# Altium schematic sheet GNSSModules.SchDoc(GNSSModules)
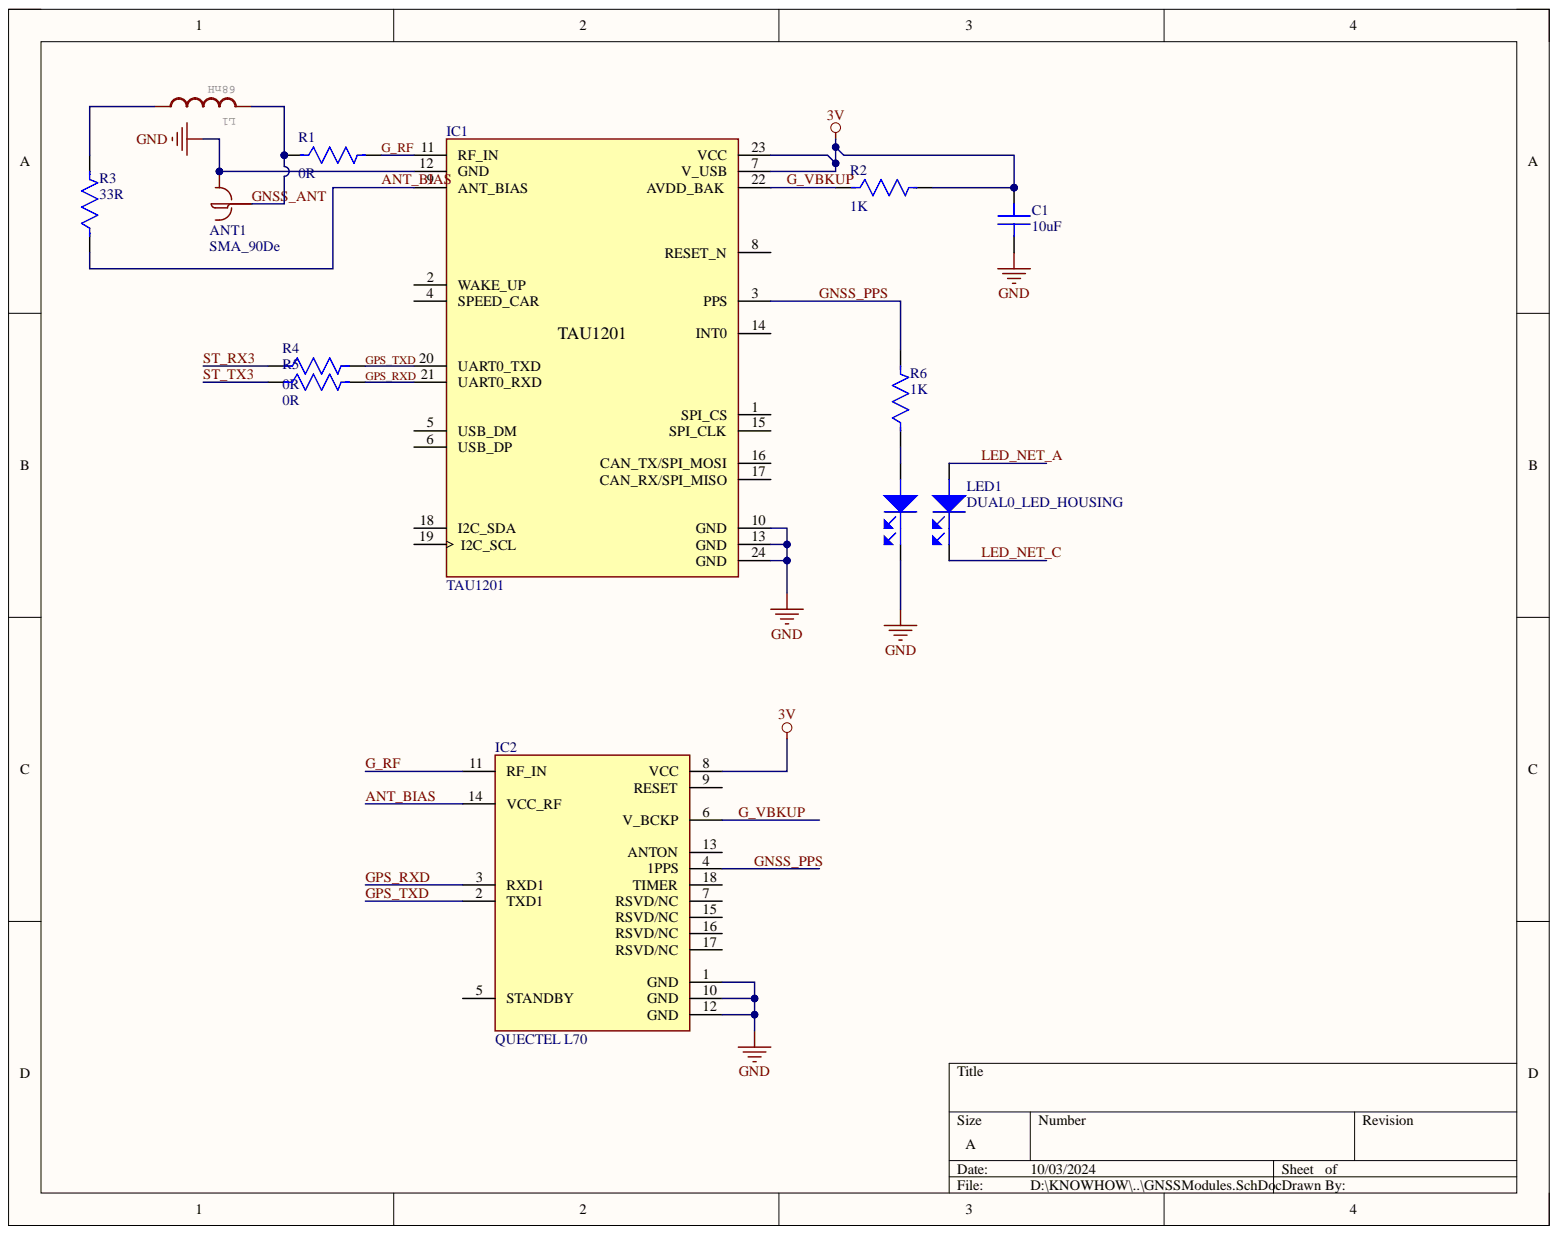
[diagram p0: GNSSModules.SchDoc]

## Parts
ANT1  SMA_90De  [SMA_90De]
C1  10uF  [C0603]
IC1  TAU1201  [GNSS_24PIN]
IC2  QUECTEL L70  MP: L70  [XCVR_L70]
L1  68nH  [C0603]
LED1  DUAL0_LED_HOUSING  [DUAL LED HOUSING]
R1  0R  [R0603]
R2  1K  [R0603]
R3  33R  [R0603]
R4  0R  [R0603]
R5  0R  [R0603]
R6  1K  [R0603]

## Nets
3V: C1.1 IC1.7(V_USB) IC1.23(VCC) IC2.8(VCC) R2.2
GND: ANT1.2, 3, 4, 5 C1.2 IC1.10(GND) IC1.12(GND) IC1.13(GND) IC1.24(GND) IC2.1(GND) IC2.10(GND) IC2.12(GND) LED1.4
ANT_BIAS: IC1.9(ANT_BIAS) IC2.14(VCC_RF) R3.1
G_RF: IC1.11(RF_IN) IC2.11(RF_IN) R1.2
G_VBKUP: IC1.22(AVDD_BAK) IC2.6(V_BCKP) R2.1
GNSS_ANT: ANT1.1 L1.2 R1.1
GNSS_PPS: IC1.3(PPS) IC2.4(1PPS) R6.2
GPS_RXD: IC1.21(UART0_RXD) IC2.3(RXD1) R5.2
GPS_TXD: IC1.20(UART0_TXD) IC2.2(TXD1) R4.2
LED_NET_A: LED1.1
LED_NET_C: LED1.2
ST_RX3: R4.1
ST_TX3: R5.1
NetL1_1: L1.1 R3.2
NetLED1_3: LED1.3 R6.1

Not connected: IC1 1(SPI_CS) 2(WAKE_UP) 4(SPEED_CAR) 5(USB_DM) 6(USB_DP) 8(RESET_N) 14(INT0) 15(SPI_CLK) 16(CAN_TX/SPI_MOSI) 17(CAN_RX/SPI_MISO) 18(I2C_SDA) 19(I2C_SCL); IC2 5(STANDBY) 7(RSVD/NC) 9(RESET) 13(ANTON) 15(RSVD/NC) 16(RSVD/NC) 17(RSVD/NC) 18(TIMER)

## Library data of the parts
C1 = Capacitor 0603: Component Kind: Standard; Library Name: UY_Schlib.SchLib
IC1 = TAU1201: Multi-Band Multi-System  GNSS Positioning Module; MF: u-blox; MP: NEO-M9N-00B; Availability: In Stock; Check_prices: https://www.snapeda.com/parts/NEO-M9N-00B/u-blox/view-part/?ref=eda; Component Kind: Standard; Package: SMD-24 u-blox; Price: None; Purchase-URL: https://pricing.snapeda.com/search/part/NEO-M9N-00B/?ref=eda; SnapEDA_Link: https://www.snapeda.com/parts/NEO-M9N-00B/u-blox/view-part/?ref=snap; Library Name: UY_Schlib.SchLib
IC2 = QUECTEL L70: GPS Module <a href="https://pricing.snapeda.com/parts/L70/Quectel/view-part?ref=eda">Check prices</a> <a href="https://pricing.snapeda.com/parts/L70/Quectel/view-part?ref=eda">Check prices</a>; Availability: Unavailable; Component Kind: Standard; Package: None; Price: None; Library Name: UY_Schlib.SchLib
L1 = Inductor 0603: DeviceSetName: L0603; GateName_1: G$1; SymbolName_1: L; Component Kind: Standard; LibraryName: Uy_SMD; Package: 0603; Library Name: UY_Schlib.SchLib
R1-R6 = Resistor 0603: SMD Resistor 0603; Manufacturer: Yageo; Manufacturer Part Number: RC0603FR-071KL; Max Power Dissipation: 100mW; Resistance Tolerance: 1%; Temperature Coefficient: 100ppm/°C; Voltage Rating: 50V; Case Code (Imperial): 0603; Case Code (Metric): 1608; Case/Package: 0603; Category: Chip SMD Resistors; Component Kind: Standard; Construction: Rectangular; Contact Plating: Tin,Matte; Depth: 0.8mm; Features: Moisture Resistant; Height: 0.45mm; Lead Free: 5697LeadFree; Length: 1.6mm; Max Operating Temperature: 155°C; Military Standard: Not; Min Operating Temperature: -55°C; Number of Terminations: 2; Packaging: Tape and Reel; Power Rating: 100mW; Pricing: 1=0.1, 10=0.018, 50=0.0106, 100=0.0088, 500=0.00592, 1000=0.00505 (USD); Reach SVHC Compliant: No; Resistor Type: Thick Film; RoHS: Yes; RoHS Compliant: Yes; Series: RC; Stock: 18662657; Supplier: Digi-Key; Supplier Part Number: 311-1.00KHRDKR-ND; Technology: THICK FILM; Termination: SMD/SMT; Tolerance: 1%; Library Name: UY_Schlib.SchLib
ANT1 = SMA_90De: Component Kind: Standard; Library Name: UY_Schlib.SchLib
LED1 = DUAL0_LED_HOUSING: Component Kind: Standard; Library Name: UY_Schlib.SchLib
